# Altium schematic sheet 主控及低压电源.SchDoc
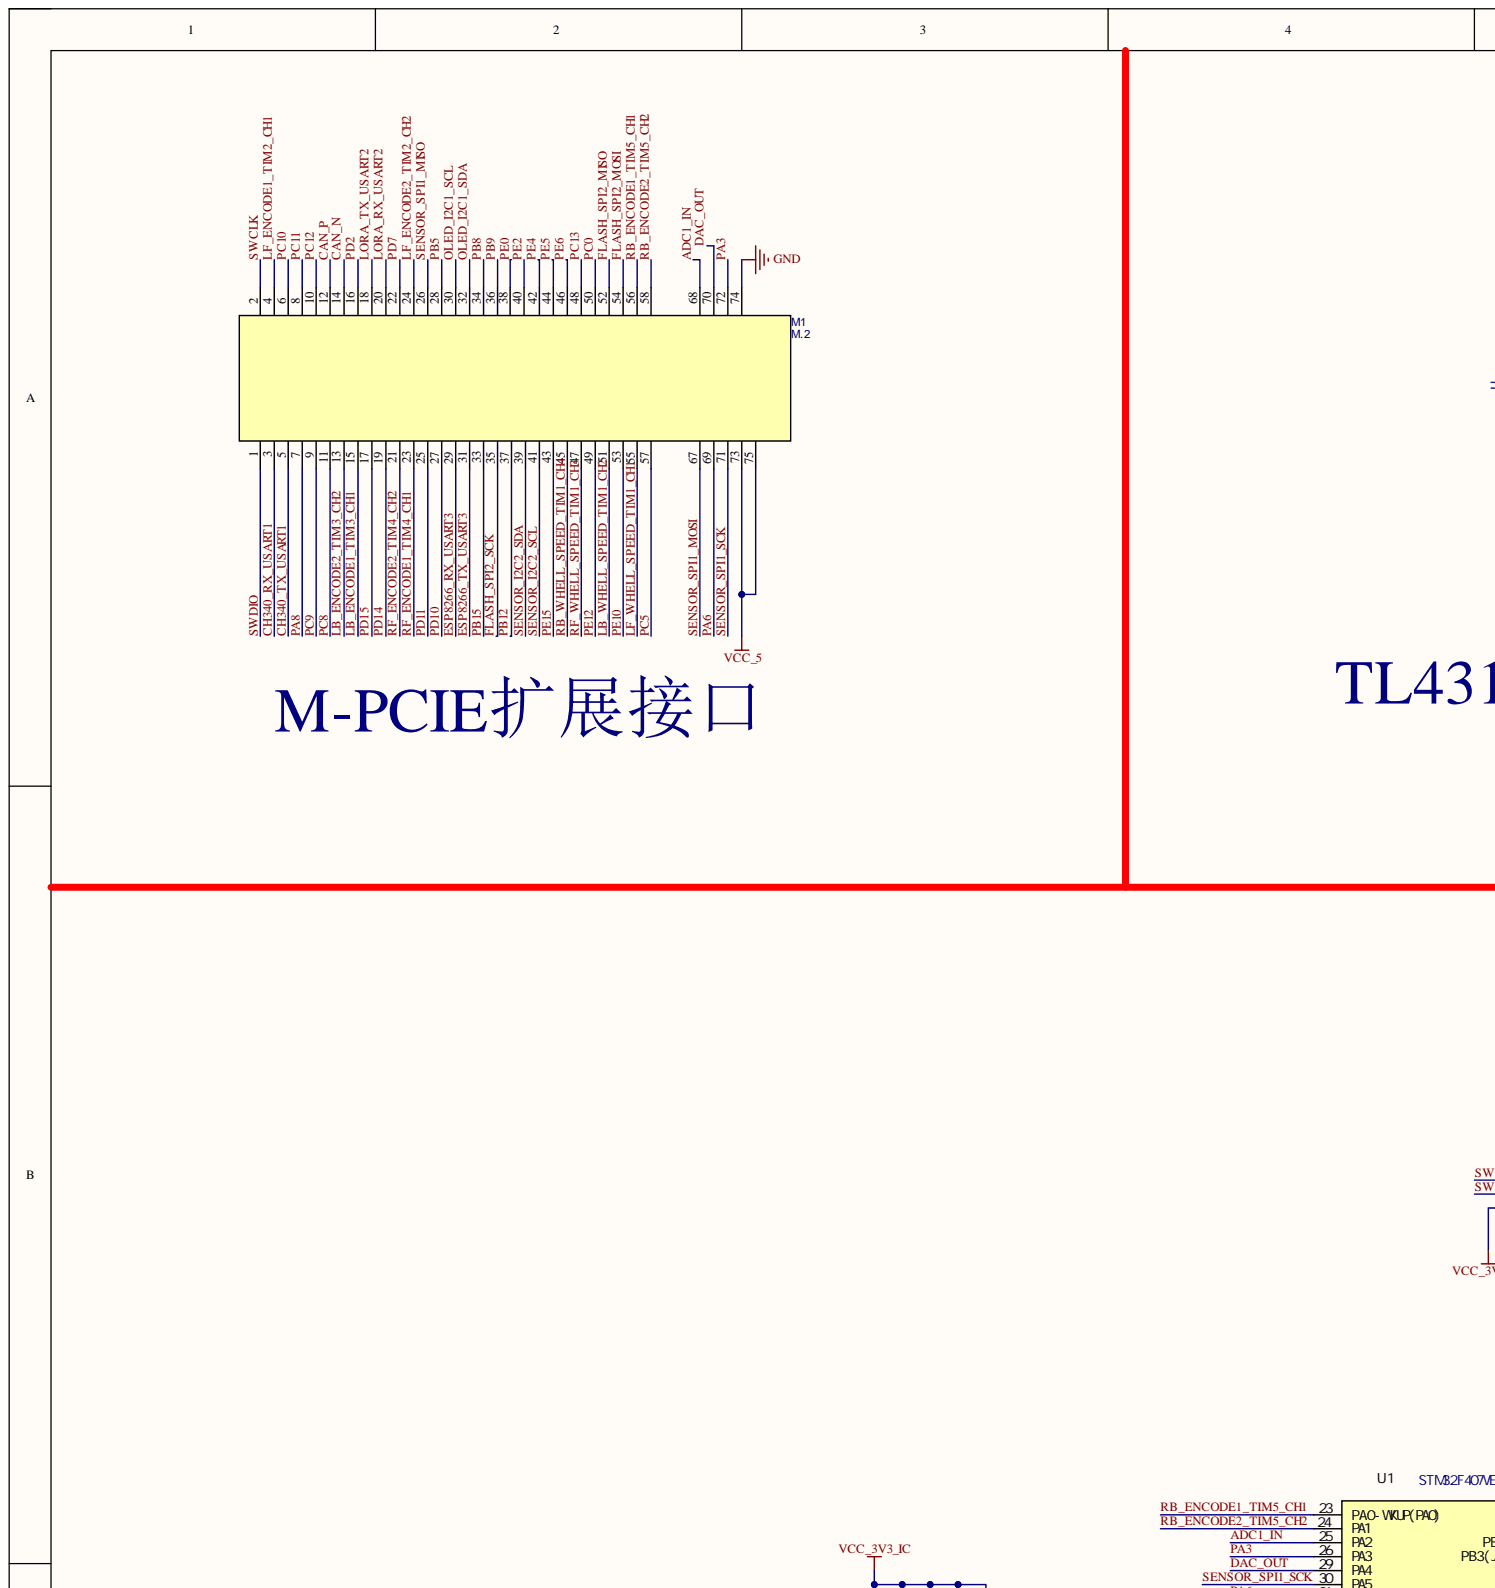
[diagram p0: 主控及低压电源.SchDoc, top left]
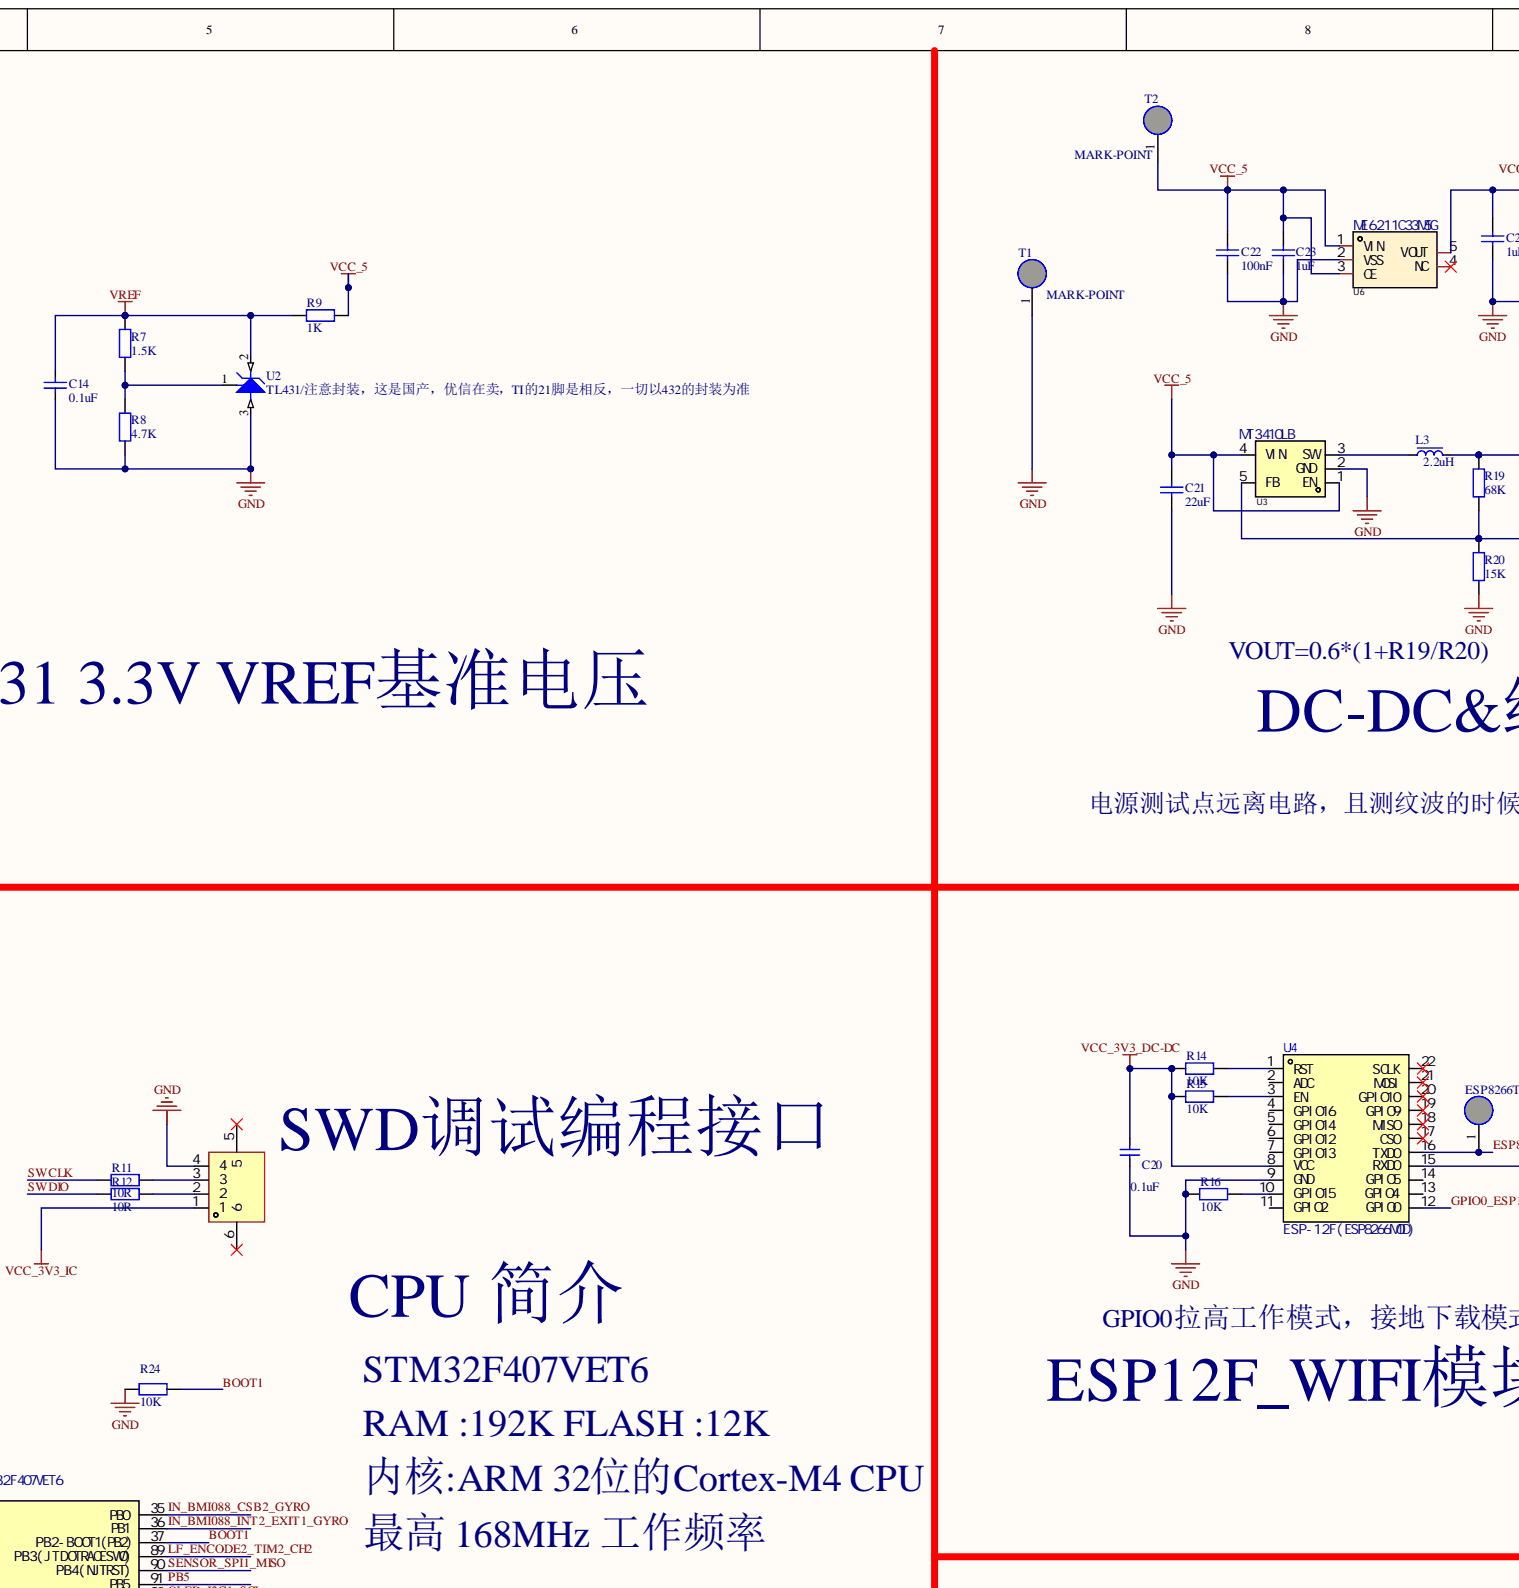
[diagram p1: 主控及低压电源.SchDoc, top centre]
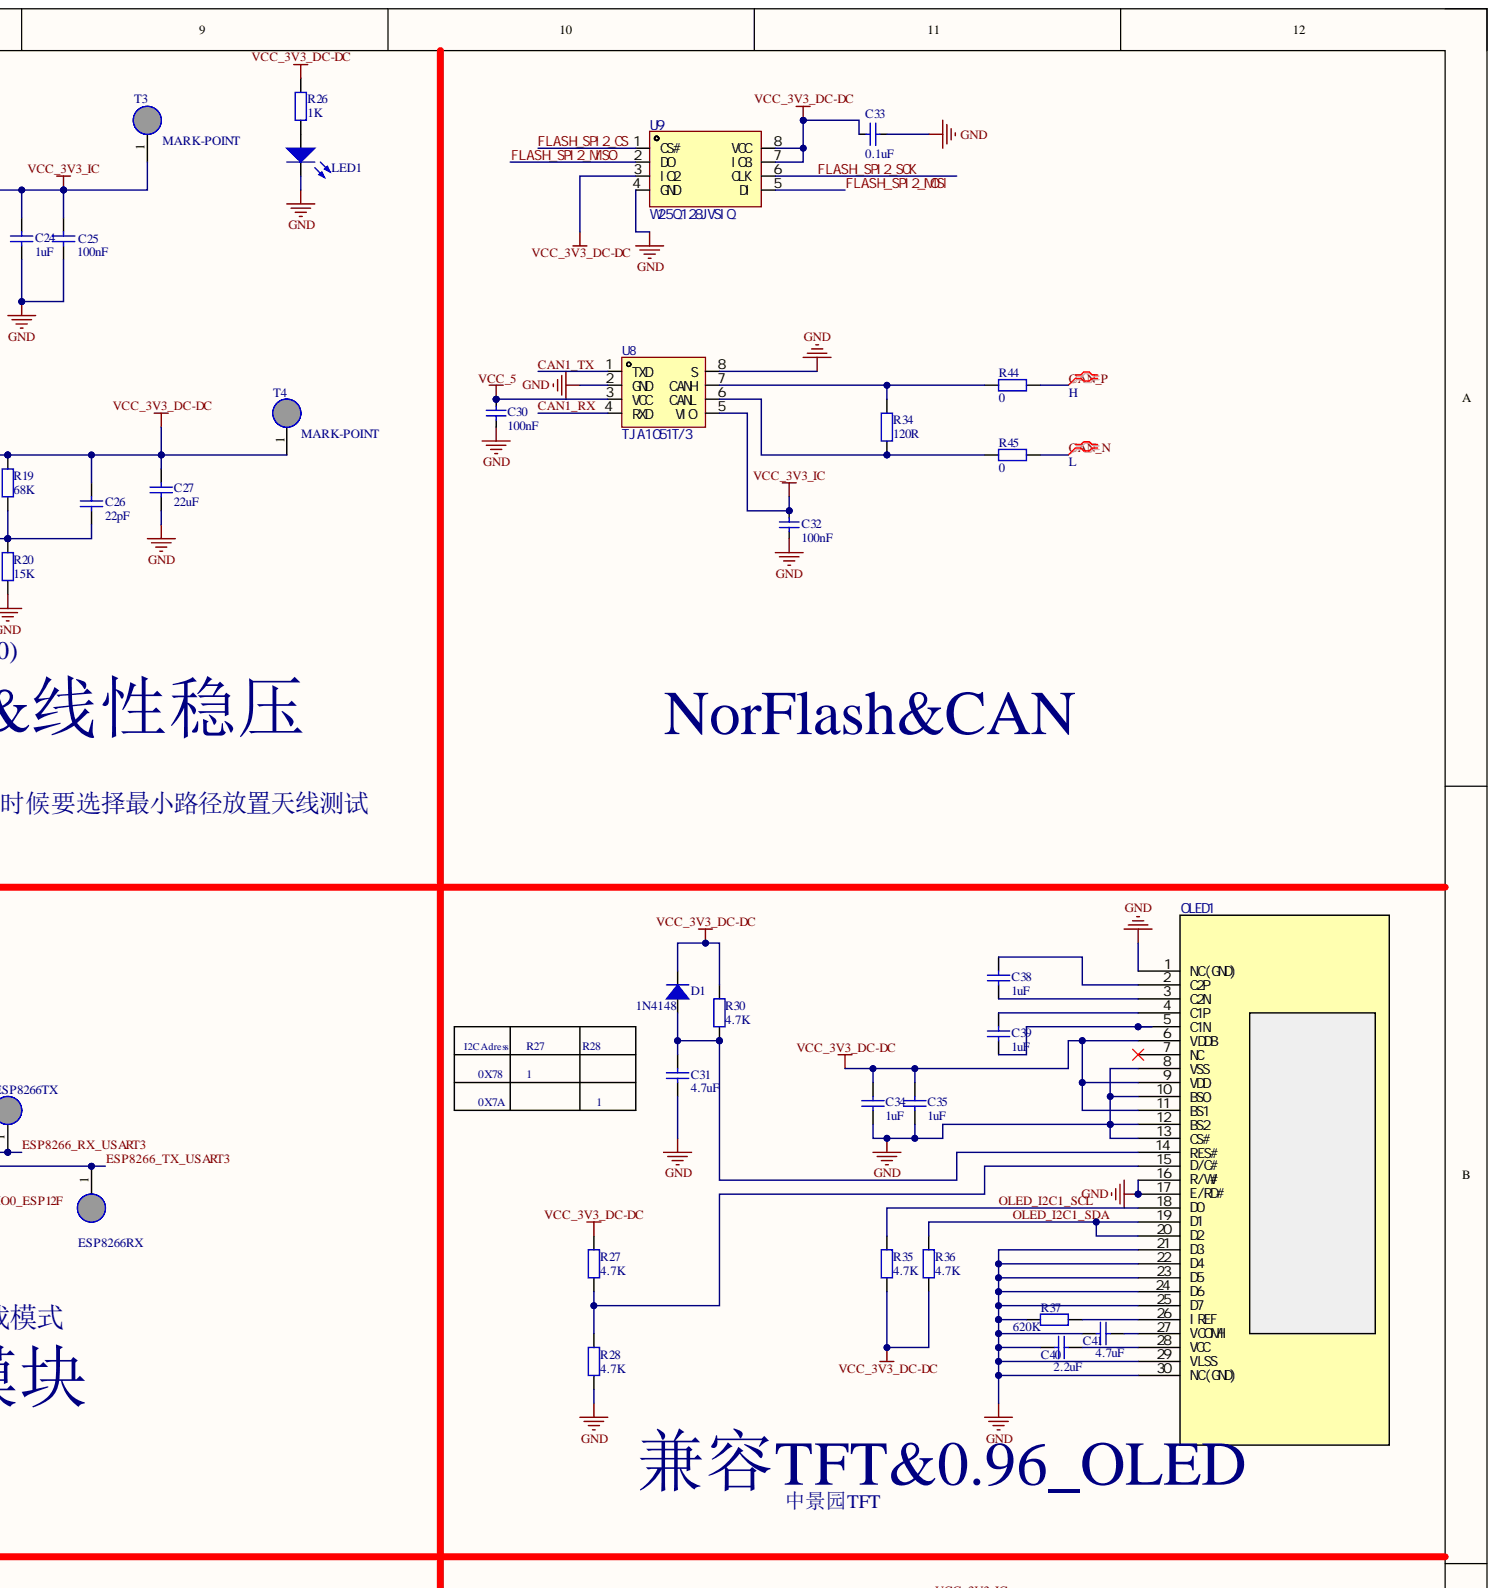
[diagram p2: 主控及低压电源.SchDoc, top right]
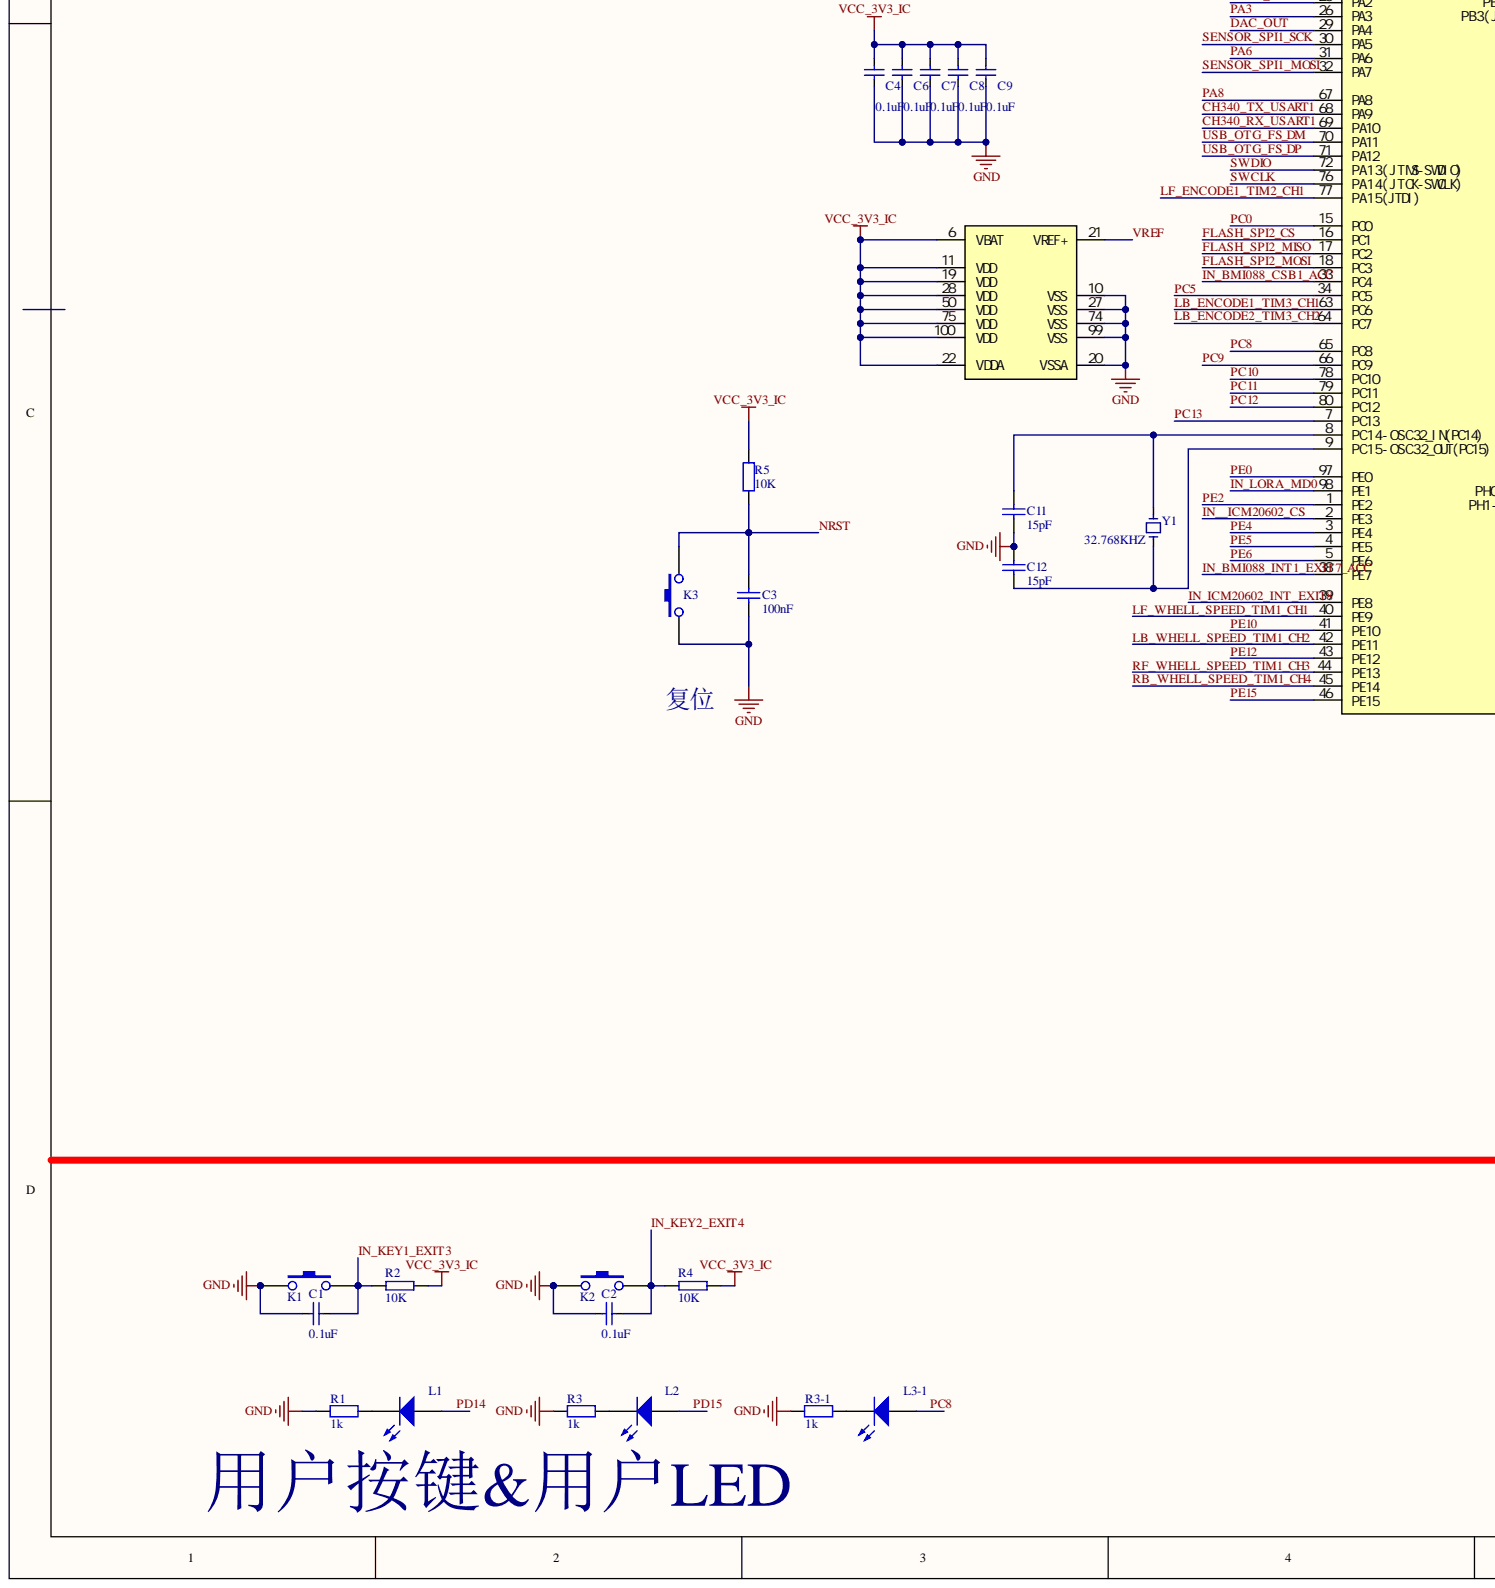
[diagram p3: 主控及低压电源.SchDoc, bottom left]
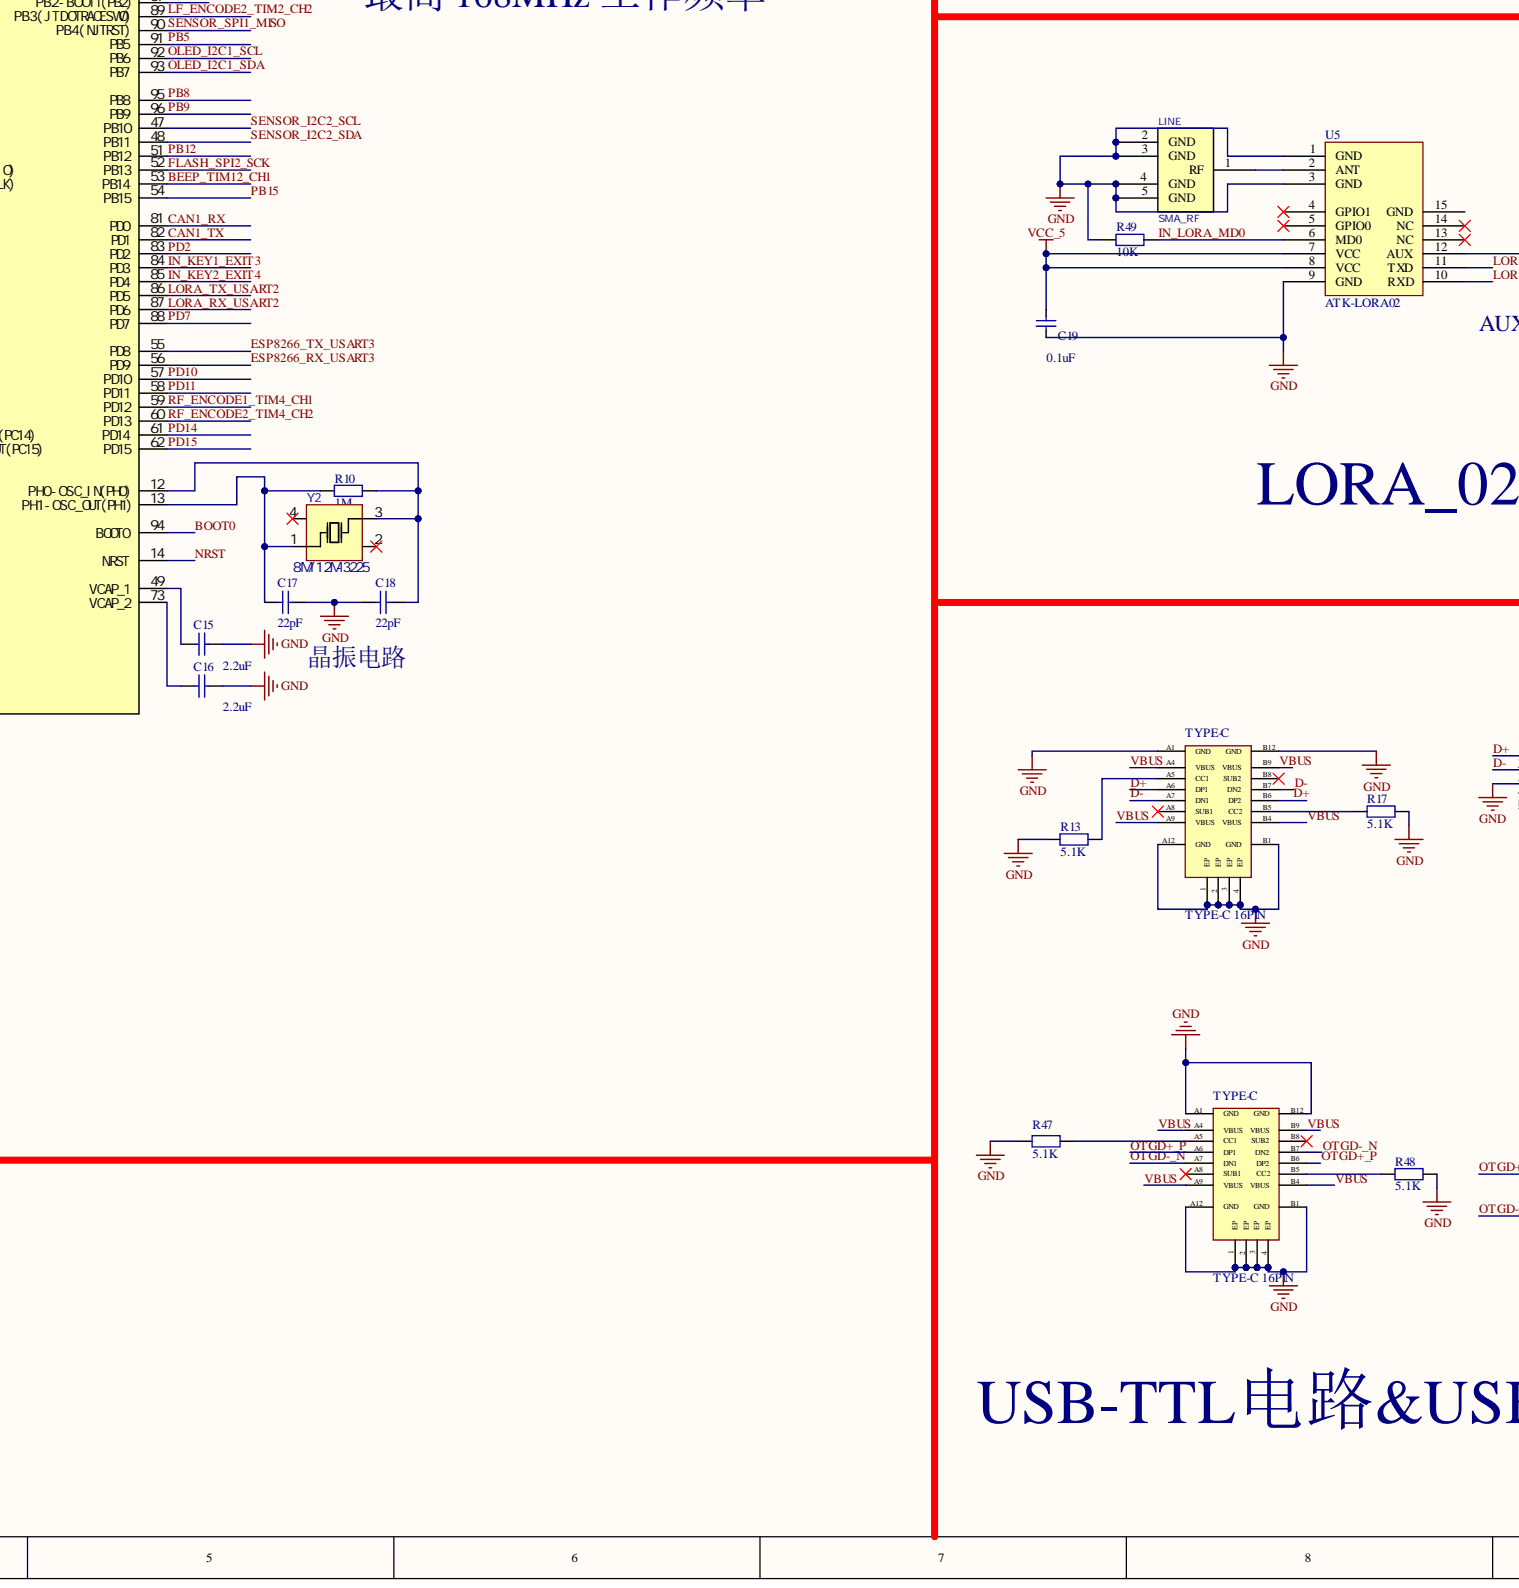
[diagram p4: 主控及低压电源.SchDoc, bottom centre]
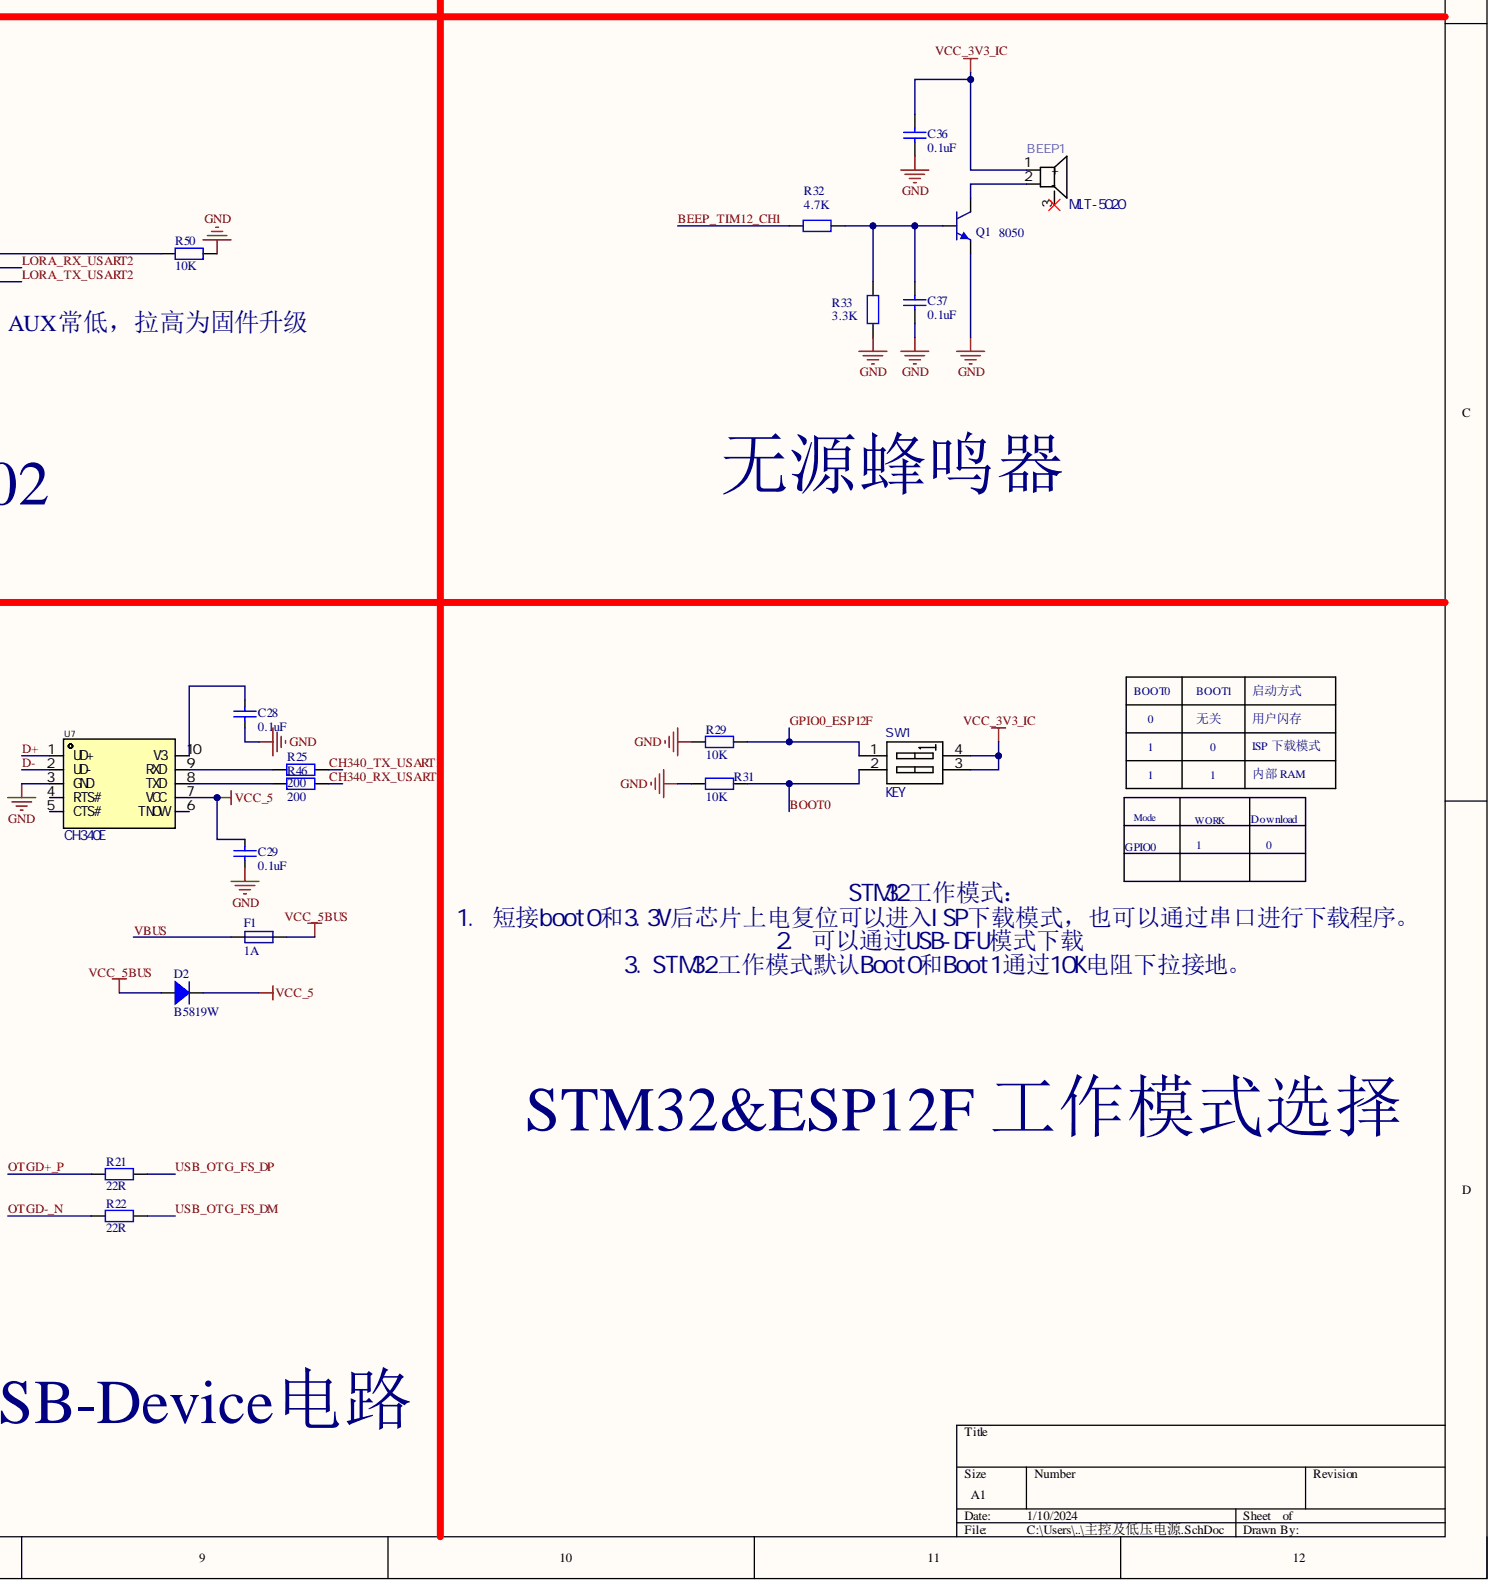
[diagram p5: 主控及低压电源.SchDoc, bottom right]

## Parts
1  TYPE-C 16PIN  [TYPE-C 16PIN]
2  TYPE-C 16PIN  [TYPE-C 16PIN]
BEEP1  MLT-5020  [BUZ-SMD_L5.0-W5.5-P4.60]
C1  0.1uF  [0805_C]
C2  0.1uF  [0805_C]
C3  100nF  [0603_C]
C4  0.1uF  [0603_C]
C6  0.1uF  [0603_C]
C7  0.1uF  [0603_C]
C8  0.1uF  [0603_C]
C9  0.1uF  [0603_C]
C11  15pF  [0603_C]
C12  15pF  [0603_C]
C14  0.1uF  [0603_C]
C15  2.2uF  [0603_C]
C16  2.2uF  [0603_C]
C17  22pF  [0603_C]
C18  22pF  [0603_C]
C19  0.1uF  [0603_C]
C20  0.1uF  [0603_C]
C21  22uF  [0603_C]
C22  100nF  [0805C]
C23  1uF  [0805_C]
C24  1uF  [0805_C]
C25  100nF  [0805C]
C26  22pF  [0603_C]
C27  22uF  [1206_C]
C28  0.1uF  [0603_C]
C29  0.1uF  [0603_C]
C30  100nF  [0603_C]
C31  4.7uF  [0603_C]
C32  100nF  [0603_C]
C33  0.1uF  [0603_C]
C34  1uF  [0603_C]
C35  1uF  [0603_C]
C36  0.1uF  [0603_C]
C37  0.1uF  [0603_C]
C38  1uF  [0603_C]
C39  1uF  [0603_C]
C40  2.2uF  [0603_C]
C41  4.7uF  [0603_C]
D1  1N4148  [SOD123]
D2  B5819W  [SOD123]
ESP8266RX  MARK-POINT  [MARK-POINT]
ESP8266TX  MARK-POINT  [MARK-POINT]
F1  value 1A  [FUSE_1206]  (hidden comment: Fuse 1)
J1  XH-4P卧贴  [CONN-SMD_2.54-4P-WT]  (value not drawn)
K1  SW-PB  [key-sop]  (value not drawn)
K2  SW-PB  [key-sop]  (value not drawn)
K3  SW-PB  [key-sop]  (value not drawn)
L1  LED0  [0603_LED]  (value not drawn)
L2  LED0  [0603_LED]  (value not drawn)
L3  2.2uH  [SMD]
L3-1  LED0  [0603_LED]  (value not drawn)
LED1  LED_0603_BLUE  [0603_LED]  (value not drawn)
LINE  SMA_RF  [SMA_RF偏脚]
M1  M.2  [M.2 M]
OLED1  OLED_0.96_30P  [oled_0.96_30p]  (value not drawn)
Q1  8050  [SOT_23]
R1  1K  [0603_R]
R2  10K  [0603_R]
R3  1K  [0603_R]
R3-1  1K  [0603_R]
R4  10K  [0603_R]
R5  10K  [0603_R]
R7  1.5K  [0603_R]
R8  4.7K  [0603_R]
R9  1K  [0603_R]
R10  1M  [0603_R]
R11  10R  [0603_R]
R12  10R  [0603_R]
R13  5.1K  [0603_R]
R14  10K  [0603_R]
R15  10K  [0603_R]
R16  10K  [0603_R]
R17  5.1K  [0603_R]
R19  68K  [0603_R]
R20  15K  [0603_R]
R21  22R  [0603_R]
R22  22R  [0603_R]
R24  10K  [0603_R]
R25  200R  value 200  [0603_R]
R26  1K  [0603_R]
R27  4.7K  [0603_R]
R28  4.7K  [0603_R]
R29  10K  [0603_R]
R30  4.7K  [0603_R]
R31  10K  [0603_R]
R32  4.7K  [0603_R]
R33  3.3K  [0603_R]
R34  120R  [0603_R]
R35  4.7K  [0603_R]
R36  4.7K  [0603_R]
R37  620K  [0603_R]
R44  0  [0603_R]
R45  0  [0603_R]
R46  200R  value 200  [0603_R]
R47  5.1K  [0603_R]
R48  5.1K  [0603_R]
R49  10K  [0603_R]
R50  10K  [0603_R]
SW1  KEY  [SW-SMD_4P_DSHP02TSGET]
T1  MARK-POINT  [MARK-POINT]
T2  MARK-POINT  [MARK-POINT]
T3  MARK-POINT  [MARK-POINT]
T4  MARK-POINT  [MARK-POINT]
U1  STM32F407VET6  [LQF-100PIN]
U2  TL431/注意封装，这是国产，优信在卖，TI的21脚是相反，一切以432的封装为准  [SOT23-3 `]
U3  MT3410LB  [SOT23-5]
U4  ESP-12F(ESP8266MOD)  [WIFIM-SMD_ESP-12F-ESP8266MOD]
U5  ATK-LORA02  [ATK-LORA02]
U6  ME6211C33M5G  [SOT23-5]
U7  CH340E  [MSOP-10_L3.0-W3.0-P0.50-LS5.0-BL]
U8  TJA1051T/3  [SOP-8]
U9  W25Q128JVSIQ  [SOIC-8_L5.3-W5.3-P1.27-LS8.0-BL]
Y1  32.768KHZ  [OSC-SMD_L3.2-W1.5]
Y2  8M/12M-3225  [OSC-SMD_4P-L3.2-W2.5-BL]

## Nets
GND: 1.1(EP) 1.2(EP) 1.3 1.4(EP) 1.A1(GND) 1.A12 1.B1 1.B12(GND) 2.1(EP) 2.2(EP) 2.3(EP) 2.4(EP) 2.A1 2.A12(GND) 2.B1(GND) 2.B12 C1.1 C2.1 C3.1 C4.2 C6.2 C7.2 C8.2 C9.2 C11.1 C12.1 C14.1 C15.2 C16.2 C17.2 C18.1 C19.2 C20.2 C21.1 C22.1 C23.1 C24.1 C25.1 C27.1 C28.1 C29.1 C30.1 C31.1 C32.1 C33.2 C34.1 C35.1 C36.2 C37.2 C40.2 C41.2 J1.4 K1.1 K2.1 K3.1 LED1.2 LINE.2 LINE.3(GND) LINE.4(GND) LINE.5(GND) M1.74 OLED1.1(NC(GND)) OLED1.8(VSS) OLED1.10(BS0) OLED1.12(BS2) OLED1.13(CS#) OLED1.16(R/W#) OLED1.17 OLED1.21(D3) OLED1.22(D4) OLED1.23(D5) OLED1.24(D6) OLED1.25(D7) OLED1.29(VLSS) OLED1.30(NC(GND)) Q1.2 R1.1 R3.1 R3-1.1 R8.2 R13.2 R16.1 R17.1 R20.2 R24.2 R28.1 R29.2 R31.2 R33.1 R37.1 R47.2 R48.1 R49.1 R50.2 T1.1 U1.10 U1.20 U1.27 U1.74 U1.99 U2.3 U3.2(GND) U4.9(GND) U5.1(GND) U5.3(GND) U5.9(GND) U6.2(VSS) U7.3(GND) U8.2(GND) U8.8(S) U9.4(GND)
VCC_3V3_DC-DC: C20.1 C26.2 C27.2 C33.1 C34.2 C35.2 D1.2 L3.2 OLED1.6(VDDB) OLED1.9(VDD) OLED1.11(BS1) R14.1 R15.1 R19.1 R26.2 R27.2 R30.2 R35.1 R36.1 T4.1 U4.8(VCC) U9.3(IO2) U9.7(IO3) U9.8(VCC)
VCC_3V3_IC: BEEP1.1 C4.1 C6.1 C7.1 C8.1 C9.1 C24.2 C25.2 C32.2 C36.1 J1.1 R2.2 R4.2 R5.2 SW1.3 SW1.4 T3.1 U1.6(VBAT) U1.11(VDD) U1.19(VDD) U1.22 U1.28 U1.50(VDD) U1.75(VDD) U1.100 U6.5(VOUT) U8.5(VIO)
VCC_5: C19.1 C21.2 C22.2 C23.2 C29.2 C30.2 D2.2 M1.73 M1.75 R9.1 T2.1 U3.1(EN) U3.4(VIN) U5.7 U5.8(VCC) U6.1(VIN) U6.3(CE) U7.7(VCC) U8.3(VCC)
VCC_5BUS: D2.1 F1.2
ADC1_IN: M1.68 U1.25
BEEP_TIM12_CH1: R32.1 U1.53(PB14)
BOOT0: R31.1 SW1.2 U1.94(BOOT0)
BOOT1: R24.1 U1.37(PB2-BOOT1(PB2))
CAN1_RX: U1.81(PD0) U8.4(RXD)
CAN1_TX: U1.82(PD1) U8.1(TXD)
CAN_N: M1.14 R45.1
CAN_P: M1.12 R44.1
CH340_RX_USART1: M1.3 R46.1 U1.69(USB_OTG_FS_DM)
CH340_TX_USART1: M1.5 R25.1 U1.68
D+: 1.A6 1.B6(CC2) U7.1(UD+)
D-: 1.A7(SUB1) 1.B7 U7.2
DAC_OUT: M1.70 U1.29(SENSOR_SPI1_SCK)
ESP8266_RX_USART3: ESP8266TX.1 M1.29 U1.56 U4.16(TXD0)
ESP8266_TX_USART3: ESP8266RX.1 M1.31 U1.55(PD8) U4.15(RXD0)
FLASH_SPI2_CS: U1.16(FLASH_SPI2_MISO) U9.1(CS#)
FLASH_SPI2_MISO: M1.52 U1.17(FLASH_SPI2_MOSI) U9.2(DO)
FLASH_SPI2_MOSI: M1.54 U1.18 U9.5(DI)
FLASH_SPI2_SCK: M1.35 U1.52(PB13) U9.6(CLK)
GPIO0_ESP12F: R29.1 SW1.1 U4.12(GPIO0)
IN__ICM20602_CS: U1.2
IN_BMI088_CSB1_ACC: U1.33
IN_BMI088_CSB2_GYRO: U1.35(PB0)
IN_BMI088_INT1_EXIT7_ACC: U1.38
IN_BMI088_INT2_EXIT1_GYRO: U1.36
IN_ICM20602_INT_EXIT8: U1.39
IN_KEY1_EXIT3: C1.2 K1.2 R2.1 U1.84(PD3)
IN_KEY2_EXIT4: C2.2 K2.2 R4.1 U1.85(PD4)
IN_LORA_MD0: R49.2 U1.98 U5.6(MD0)
LB_ENCODE1_TIM3_CH1: M1.15 U1.63(LB_ENCODE2_TIM3_CH2)
LB_ENCODE2_TIM3_CH2: M1.13 U1.64
LB_WHELL_SPEED_TIM1_CH2: M1.51 U1.42
LF_ENCODE1_TIM2_CH1: M1.4 U1.77
LF_ENCODE2_TIM2_CH2: M1.24 U1.89(PB3(JTDOTRACESWO))
LF_WHELL_SPEED_TIM1_CH1: M1.55 U1.40
LORA_RX_USART2: M1.20 U1.87(PD6) U5.11(TXD)
LORA_TX_USART2: M1.18 U1.86 U5.10(RXD)
NRST: C3.2 K3.2 R5.1 U1.14(NRST)
OLED_I2C1_SCL: M1.30 OLED1.18(D0) R35.2 U1.92(PB6)
OLED_I2C1_SDA: M1.32 OLED1.19(D1) OLED1.20 R36.2 U1.93(PB7)
OTGD+_P: 2.A6 2.B6(DP2) R21.2
OTGD-_N: 2.A7(DN1) 2.B7 R22.2
PA3: M1.72 U1.26(DAC_OUT)
PA6: M1.69 U1.31(SENSOR_SPI1_MOSI)
PA8: M1.7 U1.67(CH340_TX_USART1)
PB5: M1.28 U1.91(PB5)
PB8: M1.34 U1.95(PB8)
PB9: M1.36 U1.96(PB9)
PB12: M1.37 U1.51(PB12)
PB15: M1.33 U1.54(PB15)
PC0: M1.50 U1.15
PC5: M1.57 U1.34(LB_ENCODE1_TIM3_CH1)
PC8: L3-1.1 M1.11 U1.65
PC9: M1.9 U1.66
PC10: M1.6 U1.78
PC11: M1.8 U1.79
PC12: M1.10 U1.80
PC13: M1.48 U1.7
PD2: M1.16 U1.83
PD7: M1.22 U1.88(PD7)
PD10: M1.27 U1.57(PD10)
PD11: M1.25 U1.58(PD11)
PD14: L1.1 M1.19 U1.61(PD14)
PD15: L2.1 M1.17 U1.62(PD15)
PE0: M1.38 U1.97(IN_LORA_MD0)
PE2: M1.40 U1.1(IN__ICM20602_CS)
PE4: M1.42 U1.3
PE5: M1.44 U1.4
PE6: M1.46 U1.5
PE10: M1.53 U1.41(LB_WHELL_SPEED_TIM1_CH2)
PE12: M1.49 U1.43(RF_WHELL_SPEED_TIM1_CH3)
PE15: M1.43 U1.46
RB_ENCODE1_TIM5_CH1: M1.56 U1.23(RB_ENCODE2_TIM5_CH2)
RB_ENCODE2_TIM5_CH2: M1.58 U1.24
RB_WHELL_SPEED_TIM1_CH4: M1.45 U1.45
RF_ENCODE1_TIM4_CH1: M1.23 U1.59(PD12)
RF_ENCODE2_TIM4_CH2: M1.21 U1.60(PD13)
RF_WHELL_SPEED_TIM1_CH3: M1.47 U1.44(RB_WHELL_SPEED_TIM1_CH4)
SENSOR_I2C2_SCL: M1.41 U1.47(PB10)
SENSOR_I2C2_SDA: M1.39 U1.48
SENSOR_SPI1_MISO: M1.26 U1.90
SENSOR_SPI1_MOSI: M1.67 U1.32
SENSOR_SPI1_SCK: M1.71 U1.30
SWCLK: M1.2 R11.1 U1.76
SWDIO: M1.1 R12.1 U1.72
USB_OTG_FS_DM: R22.1 U1.70(USB_OTG_FS_DP)
USB_OTG_FS_DP: R21.1 U1.71
VBUS: 1.A4(CC1) 1.A9 1.B4 1.B9(SUB2) 2.A4(CC1) 2.A9(VBUS) 2.B4(VBUS) 2.B9(SUB2) F1.1
VREF: C14.2 R7.1 R9.2 U1.21 U2.2
Net1_A5: 1.A5(DP1) R13.1
Net1_B5: 1.B5 R17.2
Net2_A5: 2.A5 R47.1
Net2_B5: 2.B5 R48.2
NetBEEP1_2: BEEP1.2 Q1.3
NetC11_2: C11.2 U1.8 Y1.1
NetC12_2: C12.2 U1.9 Y1.2
NetC15_1: C15.1 U1.49
NetC16_1: C16.1 U1.73(VCAP_2)
NetC17_1: C17.1 R10.2 U1.13(PH1-OSC_OUT(PH1)) Y2.1
NetC18_2: C18.2 R10.1 U1.12(PH0-OSC_IN(PH0)) Y2.3
NetC26_1: C26.1 R19.2 R20.1 U3.5(FB)
NetC28_2: C28.2 U7.10(V3)
NetC31_2: C31.2 D1.1 OLED1.14(RES#) R30.1
NetC37_1: C37.1 Q1.1 R32.2 R33.2
NetC38_1: C38.1 OLED1.3(C2N)
NetC38_2: C38.2 OLED1.2(C2P)
NetC39_1: C39.1 OLED1.5(C1N)
NetC39_2: C39.2 OLED1.4(C1P)
NetC40_1: C40.1 OLED1.28(VCC)
NetC41_1: C41.1 OLED1.27(VCOMH)
NetJ1_2: J1.2 R12.2
NetJ1_3: J1.3 R11.2
NetL1_2: L1.2 R1.2
NetL2_2: L2.2 R3.2
NetL3-1_2: L3-1.2 R3-1.2
NetL3_1: L3.1 U3.3(SW)
NetLED1_1: LED1.1 R26.1
NetLINE_1: LINE.1(RF) U5.2(ANT)
NetOLED1_15: OLED1.15(D/C#) R27.1 R28.2
NetOLED1_26: OLED1.26(IREF) R37.2
NetR7_2: R7.2 R8.1 U2.1
NetR14_2: R14.2 U4.1(RST)
NetR15_2: R15.2 U4.3(EN)
NetR16_2: R16.2 U4.10
NetR25_2: R25.2 U7.9
NetR34_1: R34.1 R44.2 U8.7(CANH)
NetR34_2: R34.2 R45.2 U8.6(CANL)
NetR46_2: R46.2 U7.8(TXD)
NetR50_1: R50.1 U5.12

Not connected: 1 A8 B8(DN2); 2 A8 B8; BEEP1 3; J1 5 6; OLED1 7(NC); U4 2(ADC) 4(GPIO16) 5(GPIO14) 6(GPIO12) 7(GPIO13) 11(GPIO2) 13 14(GPIO5) 17(CS0) 18(MISO) 19(GPIO9) 20(GPIO10) 21(MOSI) 22(SCLK); U5 4(GPIO1) 5(GPIO0) 13(NC) 14(NC) 15(GND); U6 4(NC); U7 4(RTS#) 5 6; Y2 2 4

## Library data of the parts
BEEP1 = MLT-5020: Manufacturer: 华能; Add into BOM: yes; Name: 4000Hz; Oscillator Circuit: 无源(外部驱动); Technology Type: 电磁式; Value: 4000Hz; Component Kind: Standard; Convert to PCB: yes; Datasheet: https://atta.szlcsc.com/upload/public/pdf/source/20210811/111454390007D221D7259018FB170E62.pdf; Device: MLT-5020; Height: 2.5mm; JLCPCB Part Class: Extended Part; LCSC Part Name: MLT-5020; Length: 5.2mm; Manufacturer Part: MLT-5020; PCB3D: BUZ-SMD_3P-L5.2-W5.2-H2.0-P3.50; Supplier: LCSC; Supplier Footprint: SMD,5x5mm; Supplier Part: C94598; Symbol: MLT-5020; Width: 5.2mm; Library Name: KESHE.SCHLIB
C1 C2 C33 = Cap_0603: Capacitor; Component Kind: Standard; Library Name: SCH_Passive.SchLib; Value: 0.1uF
C3 C11 C12 C15-C18 C21-C27 C31 C34-C41 = CAP: Capacitor; Value: 20pF; Component Kind: Standard; Library Name: IPC14.SchLib
C4 C6-C9 C19 C20 C30 C32 = CAP1: Component Kind: Standard; Library Name: IPC14(1).SchLib
C14 C28 C29 = Cap_0805: Capacitor; Component Kind: Standard; Library Name: SCH_Passive.SchLib; Value: 0.1uF
D1 D2 = 1N4007: Component Kind: Standard; Library Name: IPC14.SchLib
F1 = Fuse 1: FUSE,Littelfuse; Component Kind: Standard; Library Name: xl_lib_v2.SchLib
J1 = XH-4P卧贴: Manufacturer: BOOMELE(博穆精密); Add into BOM: yes; Number of PINs Per Row: 4; Pins Structure: 1x4P; Pitch: 2.54mm; Reference Series: XH; Symbol: 2.54-4P; Component Kind: Standard; Contact Material: -; Contact Plating: -; Convert to PCB: yes; Current Rating (Max): -; Datasheet: https://atta.szlcsc.com/upload/public/pdf/source/20220908/79989BAB89CEC7224389904CB8712A50.pdf; Device: XH-4P卧贴; Gender: -; JLCPCB Part Class: Extended Part; LCSC Part Name: XH 2.54mm 1x4P 卧贴; Manufacturer Part: XH-4P卧贴; Mounting Style: -; Name: XH-4P卧贴; Number of Rows: 1; Operating Temperature Range: -; PCB3D: CONN-SMD_XH2.50-WS-4P; Row Spacing: -; Supplier: LCSC; Supplier Footprint: SMD,P=2.54mm; Supplier Part: C146120; Welding Temperature (Max): -; Library Name: KESHE.SCHLIB
L1 L2 L3-1 = LED0: Typical INFRARED GaAs LED; Component Kind: Standard; LatestRevisionDate: 08-Jul-2005; LatestRevisionNote: Mech Layer 1 removed.; Library Name: Miscellaneous Devices.IntLib; PackageDescription: LED; 2 Leads; PackageReference: LED-0; Published: 8-Jun-2000; Simulation: LED0; Publisher: Altium Limited
L3 = INDUCTANCE: : 2.2uH; Component Kind: Standard; Library Name: 电感-SCH.Lib
LED1 = LED_0603_BLUE: LED; Component Kind: Standard; Library Name: IPC14(1).SchLib
M1 = M.2: Contributor: LAXXI; Component Kind: Standard; spicePre: U; spiceSymbolName: M.2; Library Name: KESHE.SCHLIB
OLED1 = OLED_0.96_30P: Manufacturer: WiseChip; Manufacturer Part: VG-2864KSWEG01; Add into BOM: yes; Pin Type: IN; Component Kind: Standard; Convert to PCB: yes; Device: OLED_0.96_30P; JLCPCB Part Class: 扩展库; Supplier: LCSC; Supplier Part: C194313; Symbol: OLED_0.96_30P; Library Name: KESHE.SCHLIB
Q1 = S8050: NPN; Value: S8050; Component Kind: Standard; Library Name: xl_lib_v1.SchLib
R1 R3 R3-1 = Res2: Resistor; Component Kind: Standard; LatestRevisionDate: 17-Jul-2002; LatestRevisionNote: Re-released for DXP Platform.; Library Name: Miscellaneous Devices.IntLib; PackageDescription: Axial Device, Thru-Hole; 2 Leads; 0.4 in Pin Spacing; PackageReference: AXIAL-0.4; Published: 8-Jun-2000; Signal Integrity: Res; Simulation: RESISTOR; Value: 1k; Publisher: Altium Limited
R2 R4 = RES_10K: : 10K; Component Kind: Standard; Library Name: Flyking 常用元件.SchLib
R5 R7 R8 R14-R16 R19 R20 R27 R28 R30 R34-R37 R49 R50 = RES: Ressitor; Value: 1K; Component Kind: Standard
  R5 R7 R8 R19 R20: Library Name: IPC14.SchLib
  R14-R16 R27 R28 R30 R34-R37 R49 R50: Library Name: IPC14(1).SchLib
R9 R26 = RES: Ressitor; Component Kind: Standard; Library Name: IPC14.SchLib; Value: 1K
R10-R13 R17 R21 R22 R24 R25 R29 R31-R33 R44-R48 = Res_0603: Resistor; Component Kind: Standard; Library Name: SCH_Passive.SchLib
  R10: Value: 1M
  R11 R12: Value: 10R
  R13 R17 R47 R48: Value: 5.1K
  R21 R22: Value: 22R
  R24 R29 R31: Value: 10K
  R32: Value: 4.7K
  R33: Value: 3.3K
  R44 R45: Value: 0
SW1 = DSHP02TSGET: Manufacturer: YE; Add into BOM: yes; Circuit: 单刀单掷; Number of Positions: 2; Color: 黑色; Component Kind: Standard; Convert to PCB: yes; Datasheet: https://atta.szlcsc.com/upload/public/pdf/source/20150928/1457707425261.pdf; Device: DSHP02TSGET; JLCPCB Part Class: Extended Part; LCSC Part Name: 2位 1.27间距; Lead Pitch: 1.27mm; Manufacturer Part: DSHP02TSGET; Name: DSHP02TSGET; PCB3D: SW-SMD_4P-L4.2-W5.4-H2.3-LS8.4-P1.27; Supplier: LCSC; Supplier Footprint: SMD-4P,4.1x5.4mm; Supplier Part: C40735; Symbol: DSHP02TSGET; Type: 平拨，凹槽式; Library Name: KESHE.SCHLIB
U1 = STM32F407VET6: Manufacturer: ST(意法半导体); ADC (Units/Channels/bits): 1@x16ch/12bit; Add into BOM: yes; CPU Core: ARM Cortex-M4; DAC (Units/Channels/bits): 1@x2ch/12bit; GPIO Ports Number: 82; Internal Oscillator: 有; Maximum Frequency: 168MHz; Peripherals / Functions / Protocol Stacks: 欠压检测/复位；DMA；LCD驱动；看门狗；POR；PWM; Program FLASH Size: 512K@x8bit; RAM Size: 192KB; Supply Voltage Range: 1.8V~3.6V; Component Kind: Standard; Convert to PCB: yes; Datasheet: https://atta.szlcsc.com/upload/public/pdf/source/20140322/1457707027869.pdf; Device: STM32F407VET6; EEPROM/Data FLASH Size: -; JLCPCB Part Class: Extended Part; LCSC Part Name: ARM Cortex-M4 168MHz 闪存：512K@x8bit RAM：192KB; Manufacturer Part: STM32F407VET6; Name: STM32F407VET6; Operating Temperature Range: -40℃~+85℃; Supplier: LCSC; Supplier Footprint: LQFP-100(14x14); Supplier Part: C28730; Symbol: STM32F407VET6; Library Name: KESHE.SCHLIB
U2 = TL431: IC; Component Kind: Standard; Library Name: IPC14.SchLib
U3 = MT3410LB: Manufacturer: 西安航天民芯; Add into BOM: yes; Component Kind: Standard; Convert to PCB: yes; Datasheet: https://atta.szlcsc.com/upload/public/pdf/source/20201021/C883494_10DFCA7CC096175FD05A8C016AA3F473.pdf; Device: MT3410LB; JLCPCB Part Class: Extended Part; LCSC Part Name: MT3410LB; Manufacturer Part: MT3410LB; Name: MT3410LB; Supplier: LCSC; Supplier Footprint: SOT-23-5; Supplier Part: C883494; Symbol: MT3410LB; Library Name: KESHE.SCHLIB
U4 = ESP-12F(ESP8266MOD): Manufacturer: Ai-Thinker(安信可); Add into BOM: yes; Antenna Type: PCB 板载天线; Name: 2.4GHz; Operating Voltage: 3.3V; Output Power: 16dBm; Receive  Current: 120mA; Send  Current: 170mA; Sensitivity: -90dBm; Support Interface: GPIO；UART；I2C；PWM；SPI；ADC; Value: 2.4GHz; Wireless Standard: 802.11b/g/n; Component Kind: Standard; Convert to PCB: yes; Core Processor: ESP8266; Datasheet: https://atta.szlcsc.com/upload/public/pdf/source/20210219/C82891_ABD84A460F4F056A2757267CCCA4B508.pdf; Device: ESP-12F(ESP8266MOD); JLCPCB Part Class: Extended Part; LCSC Part Name: 完整的802.11b/g/n Wi-Fi的SoC模块; Manufacturer Part: ESP-12F(ESP8266MOD); Operating Temperature: -40℃~+85℃; Supplier: LCSC; Supplier Footprint: SMD,16x24mm; Supplier Part: C82891; Symbol: ESP-12F(ESP8266MOD); Library Name: KESHE.SCHLIB
U5 = LORA02: Component Kind: Standard; Library Name: KESHE.SCHLIB
U6 = ME6211C25M5G: Manufacturer: MICRONE(南京微盟); Add into BOM: yes; Component Kind: Standard; Convert to PCB: yes; Datasheet: https://atta.szlcsc.com/upload/public/pdf/source/20211210/ACC7C3E9BA56F250BF966425916E32B9.pdf; Device: ME6211C25M5G; JLCPCB Part Class: Extended Part; LCSC Part Name: ME6211C25M5G; Library Name: ME6211C25M5G1_ME6211C25M5G.SCHLIB; Manufacturer Part: ME6211C25M5G; Name: ME6211C25M5G; PCB3D: SOT-23-5_L2.9-W1.7-P0.95-LS2.8-BL; Supplier: LCSC; Supplier Footprint: SOT23-5; Supplier Part: C2835813; Symbol: ME6211C25M5G
U7 = CH340E: Manufacturer: WCH(南京沁恒); Add into BOM: yes; Applications Function: 收发器; Data Rate: 2Mbps; Protocol: USB 2.0; Supply Voltage: 3.3V；5V; Component Kind: Standard; Convert to PCB: yes; Datasheet: https://atta.szlcsc.com/upload/public/pdf/source/20200819/C99652_97FFC0DF2539BFF05CD752AD9221D632.pdf; Device: CH340E; JLCPCB Part Class: Extended Part; LCSC Part Name: USB转串口芯片 CH340; Manufacturer Part: CH340E; Name: CH340E; PCB3D: MSOP-10_L3.0-W3.0-H1.1-LS5.0-P0.50; Supplier: LCSC; Supplier Footprint: MSOP-10; Supplier Part: C99652; Symbol: CH340E; Library Name: KESHE.SCHLIB
U8 = TJA1051T/3: Manufacturer: Tokmas(托克马斯); Add into BOM: yes; Component Kind: Standard; Convert to PCB: yes; Datasheet: https://atta.szlcsc.com/upload/public/pdf/source/20221230/A2F88B671123D34174A4F40D8F54A86B.pdf; Device: TJA1051T/3; JLCPCB Part Class: Extended Part; LCSC Part Name: CAN芯片; Manufacturer Part: TJA1051T/3; Name: TJA1051T/3; Supplier: LCSC; Supplier Footprint: SOP-8; Supplier Part: C5342108; Symbol: TJA1051T/3; Library Name: KESHE.SCHLIB
U9 = W25Q128JVSIQ: 128Mbit(16MBx8bit),高速版,; Manufacturer: WINBOND(华邦); Add into BOM: yes; Symbol: W25Q128JVSIQTR; Component Kind: Standard; Convert to PCB: yes; Datasheet: https://atta.szlcsc.com/upload/public/pdf/source/20170316/1489627409956.pdf; Device: W25Q128JVSIQ; JLCPCB Part Class: 基础库; LCSC Part Name: W25Q128JVSIQ; Manufacturer Part: W25Q128JVSIQ; Name: W25Q128JVSIQ; PCB3D: SOIC-8_L5.3-W5.3-H1.9-LS7.9-P1.27; Supplier: LCSC; Supplier Footprint: SOIC-8-208mil; Supplier Part: C97521; Library Name: KESHE.SCHLIB
Y1 = 晶振: YST310S; Component Kind: Standard; Library Name: IPC14.SchLib
Y2 = X322512MSB4SI: 无源晶振; Manufacturer: YXC扬兴科技; Add into BOM: yes; Crystal Type: 贴片无源晶振; Frequency Stability: ±30ppm; Frequency Tolerance: ±10ppm; Load Capacitance: 20pF; Name: 12MHz; Value: 12MHz; Component Kind: Standard; Convert to PCB: yes; Datasheet: https://atta.szlcsc.com/upload/public/pdf/source/20210329/C112971_1F611BDC486E193C1706F6D8EB5AA189.pdf; Device: X322512MSB4SI; JLCPCB Part Class: Basic Part; LCSC Part Name: 12MHz ±10ppm 20pF; Manufacturer Part: X322512MSB4SI; Operating Temperature: -40℃~+85℃; Supplier: LCSC; Supplier Footprint: SMD3225-4P; Supplier Part: C9002; Symbol: X322512MSB4SI; Library Name: KESHE.SCHLIB
1 2 = TYPE-C 16PIN: Component Kind: Standard; Library Name: IPC14.SchLib
ESP8266RX ESP8266TX T1-T4 = MARK-POINT: Component Kind: Standard; Library Name: KESHE.SCHLIB
K1-K3 = SW-PB: Component Kind: Standard; Library Name: SW开关.SchLib
LINE = SMA_RF: Component Kind: Standard; Library Name: KESHE.SCHLIB
